# Altium schematic sheet JacdacEc30Template20x20 80.SchDoc
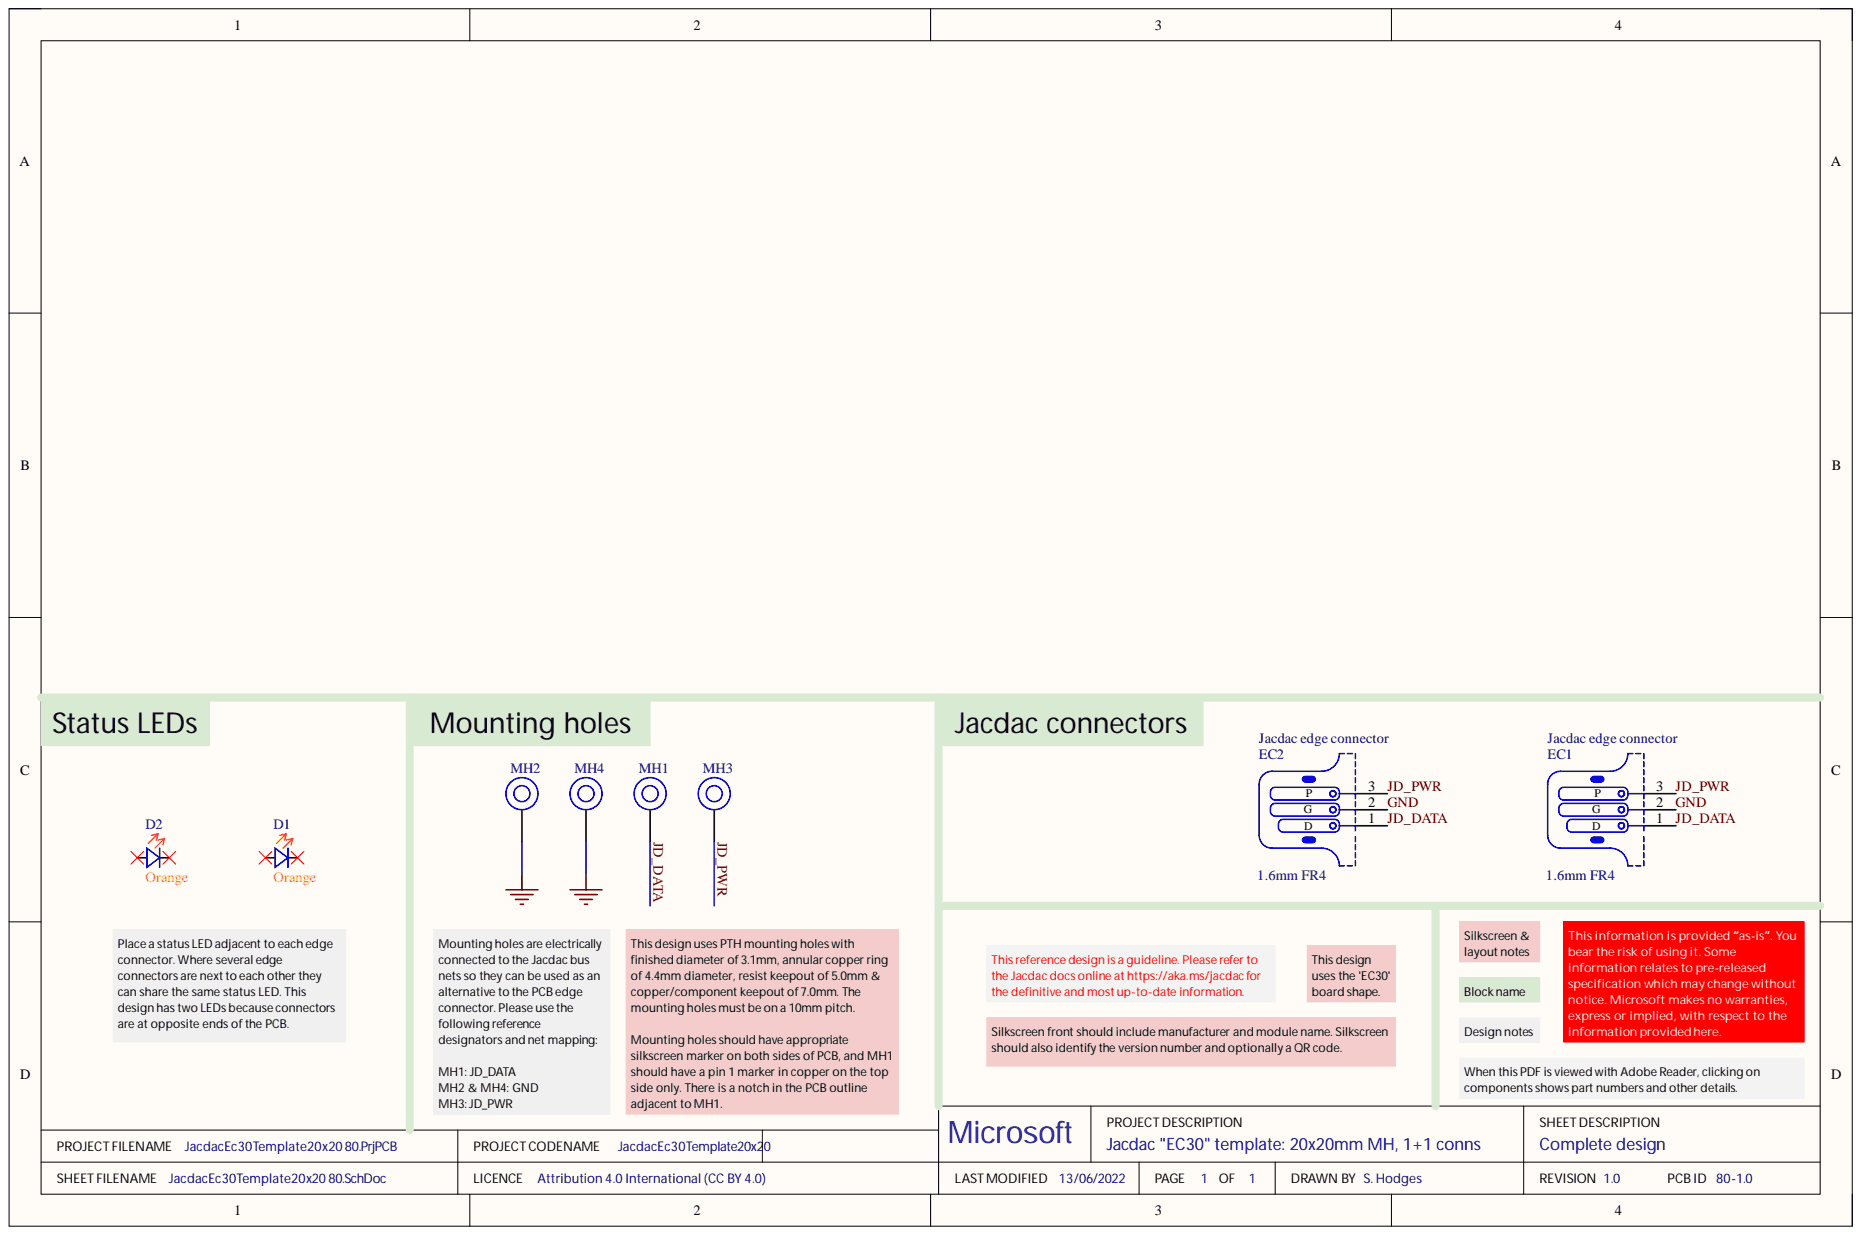
[diagram p0: JacdacEc30Template20x20 80.SchDoc]

## Parts
D1  Color: Orange
D2  Color: Orange
EC1  PCB Type: 1.6mm FR4
EC2  PCB Type: 1.6mm FR4
MH1  (no value)
MH2  (no value)
MH3  (no value)
MH4  (no value)

## Nets
GND: EC1.2 EC2.2 MH2.1 MH4.1
JD_DATA: EC1.1 EC2.1 MH1.1
JD_PWR: EC1.3 EC2.3 MH3.1

Not connected: D1 1 2; D2 1 2

## Library data of the parts
D1 D2 = (no symbol name): LED ORANGE DIFFUSED 0603 SMD; Manufacturer: Everlight Elec; Manufacturer Part Number: 19-217/S2C-AQ1R1B/3T; Case Code (Imperial): 0603; Case/Package: 0603; Colour: Orange; ComponentLink1Description: Online datasheet; ComponentLink1URL: https://datasheet.lcsc.com/lcsc/1912171731_Everlight-Elec-19-217-S2C-AQ1R1B-3T_C146215.pdf; Supplier 1: LCSC; Supplier 1 Part Number: C146215
EC1 EC2 = (no symbol name): 3 pin Jacdac edge connector 1.27mm pitch; Manufacturer Part Number: JACDAC_EDGE_CONNECTOR; ComponentLink1Description: Yuliang JACDAC cable connector drawing online; ComponentLink1URL: https://github.com/microsoft/jacdac-mdk/blob/main/connector/JACDAC-Connector-by-Yuliang-2020-11-28.pdf; ComponentLink2Description: JACDAC PCB edge connector spec onlin; ComponentLink2URL: https://github.com/microsoft/jacdac-mdk/blob/main/connector/JACDAC_PCB_Edge_Connector_Drawing_JD-PEC-01_rev_01.pdf
MH1-MH4 = (no symbol name): Mounting Hole 6.5mm keepout, 4.5mm annualar ring and 3.0mm Drill PTH
